# Altium schematic sheet Clock.SchDoc(Clock)
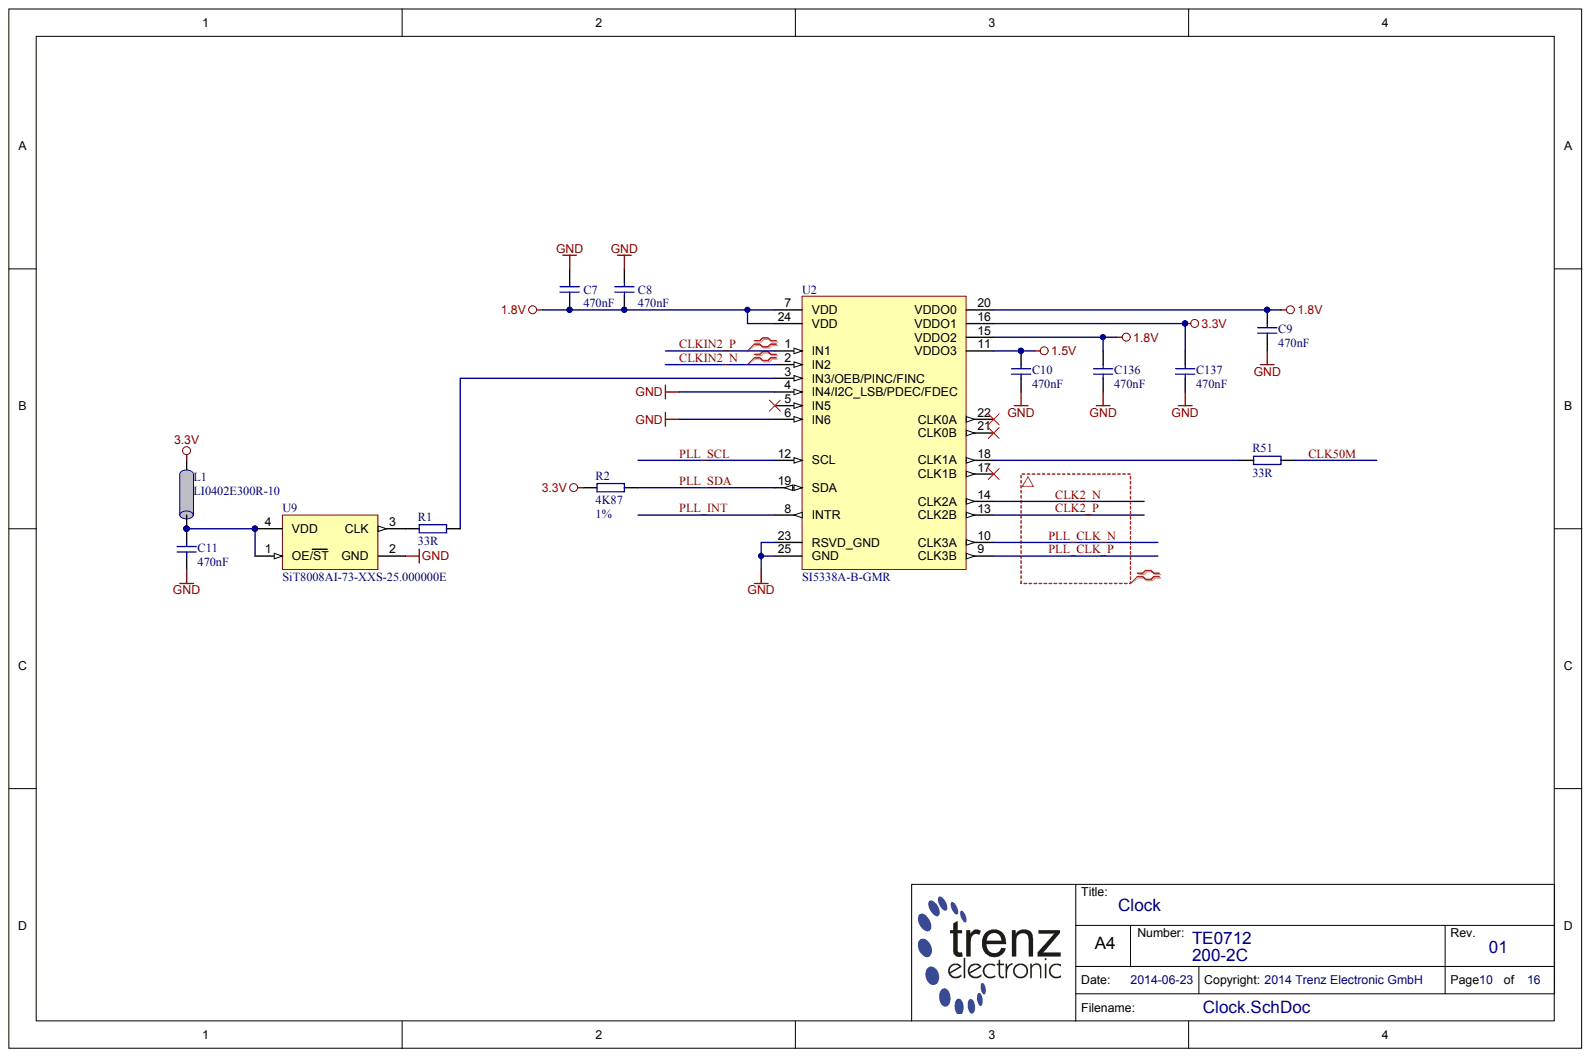
[diagram p0: Clock.SchDoc]

## Parts
C7  470nF
C8  470nF
C9  470nF
C10  470nF
C11  470nF
C136  470nF
C137  470nF
L1  LI0402E300R-10
R1  33R
R2  4K87  Tolerance: 1%
R51  33R
U2  SI5338A-B-GMR
U9  SiT8008AI-73-XXS-25.000000E

## Nets
1.5V: C10.1 U2.11(VDDO3)
1.8V: C7.1 C8.1 C9.1 C136.1 U2.7(VDD) U2.15(VDDO2) U2.20(VDDO0) U2.24(VDD)
3.3V: C137.1 L1.1 R2.1 U2.16(VDDO1)
GND: C7.2 C8.2 C9.2 C10.2 C11.2 C136.2 C137.2 U2.4(IN4/I2C_LSB/PDEC/FDEC) U2.6(IN6) U2.23(RSVD_GND) U2.25(GND) U9.2(GND)
CLK2_N: U2.14(CLK2A)
CLK2_P: U2.13(CLK2B)
CLK50M: R51.2
CLKIN2_N: U2.2(IN2)
CLKIN2_P: U2.1(IN1)
PLL_CLK_N: U2.10(CLK3A)
PLL_CLK_P: U2.9(CLK3B)
PLL_INT: U2.8(INTR)
PLL_SCL: U2.12(SCL)
PLL_SDA: R2.2 U2.19(SDA)
NetC11_1: C11.1 L1.2 U9.1(OE/ST) U9.4(VDD)
NetR1_1: R1.1 U9.3(CLK)
NetR1_2: R1.2 U2.3(IN3/OEB/PINC/FINC)
NetR51_1: R51.1 U2.18(CLK1A)
N00532:

Not connected: U2 5(IN5) 17(CLK1B) 21(CLK0B) 22(CLK0A)

## Library data of the parts
C7-C11 C136 C137 = (no symbol name): AN: 24921; Value: 470n; Voltage: 6.3V; Tolerance: 20%; Mixed: SMT; Preferred: Yes; Case: 0603 (0201); Technology: X5R
L1 = (no symbol name): AN: 24681; Mixed: SMT; Preferred: Yes; Voltage: 500mA; Case: 1005 (0402); Technology: 0.3Ohm; HelpURL: B:\AD\adlib\datasheet\LI0402E300R-10
R1 R51 = (no symbol name): AN: 25021; Value: 33; Mixed: SMT; Preferred: Yes; Case: 0603 (0201); HelpURL: B:\AD\adlib\datasheet\R0201
R2 = (no symbol name): AN: 25921; Value: 4.87k; Mixed: SMT; Preferred: Yes; Case: 1005 (0402)
U2 = (no symbol name): AN: 25121; Trenz-Stock: Yes; Mixed: SMT; Case: QFN24; Preferred: Yes; HelpURL: B:\AD\adlib\datasheet\SI5338A
U9 = (no symbol name): AN: 25008; Value: 25M; Trenz-Stock: Yes; Mixed: SMT; Case: 2.0  x 1.6mm; Preferred: Yes; HelpURL: B:\AD\adlib\datasheet\SiT8008
